# Altium schematic sheet Gateway_Main_Board.SchDoc
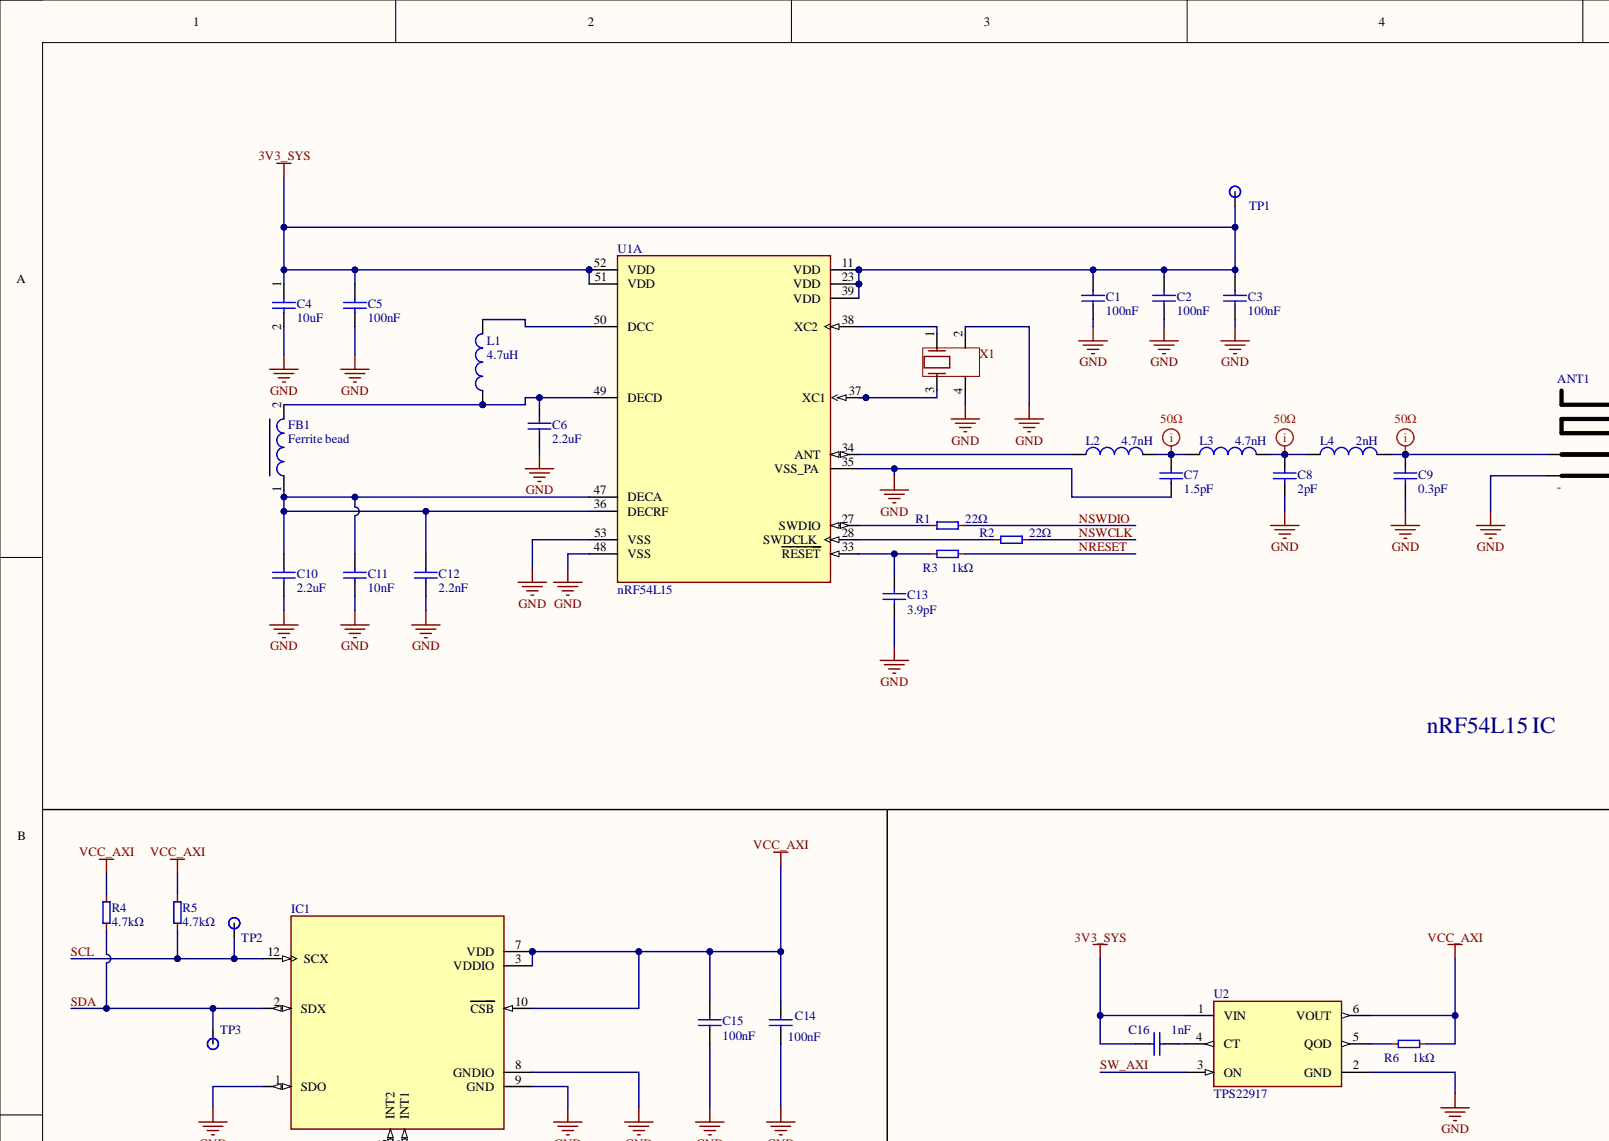
[diagram p0: Gateway_Main_Board.SchDoc, top left]
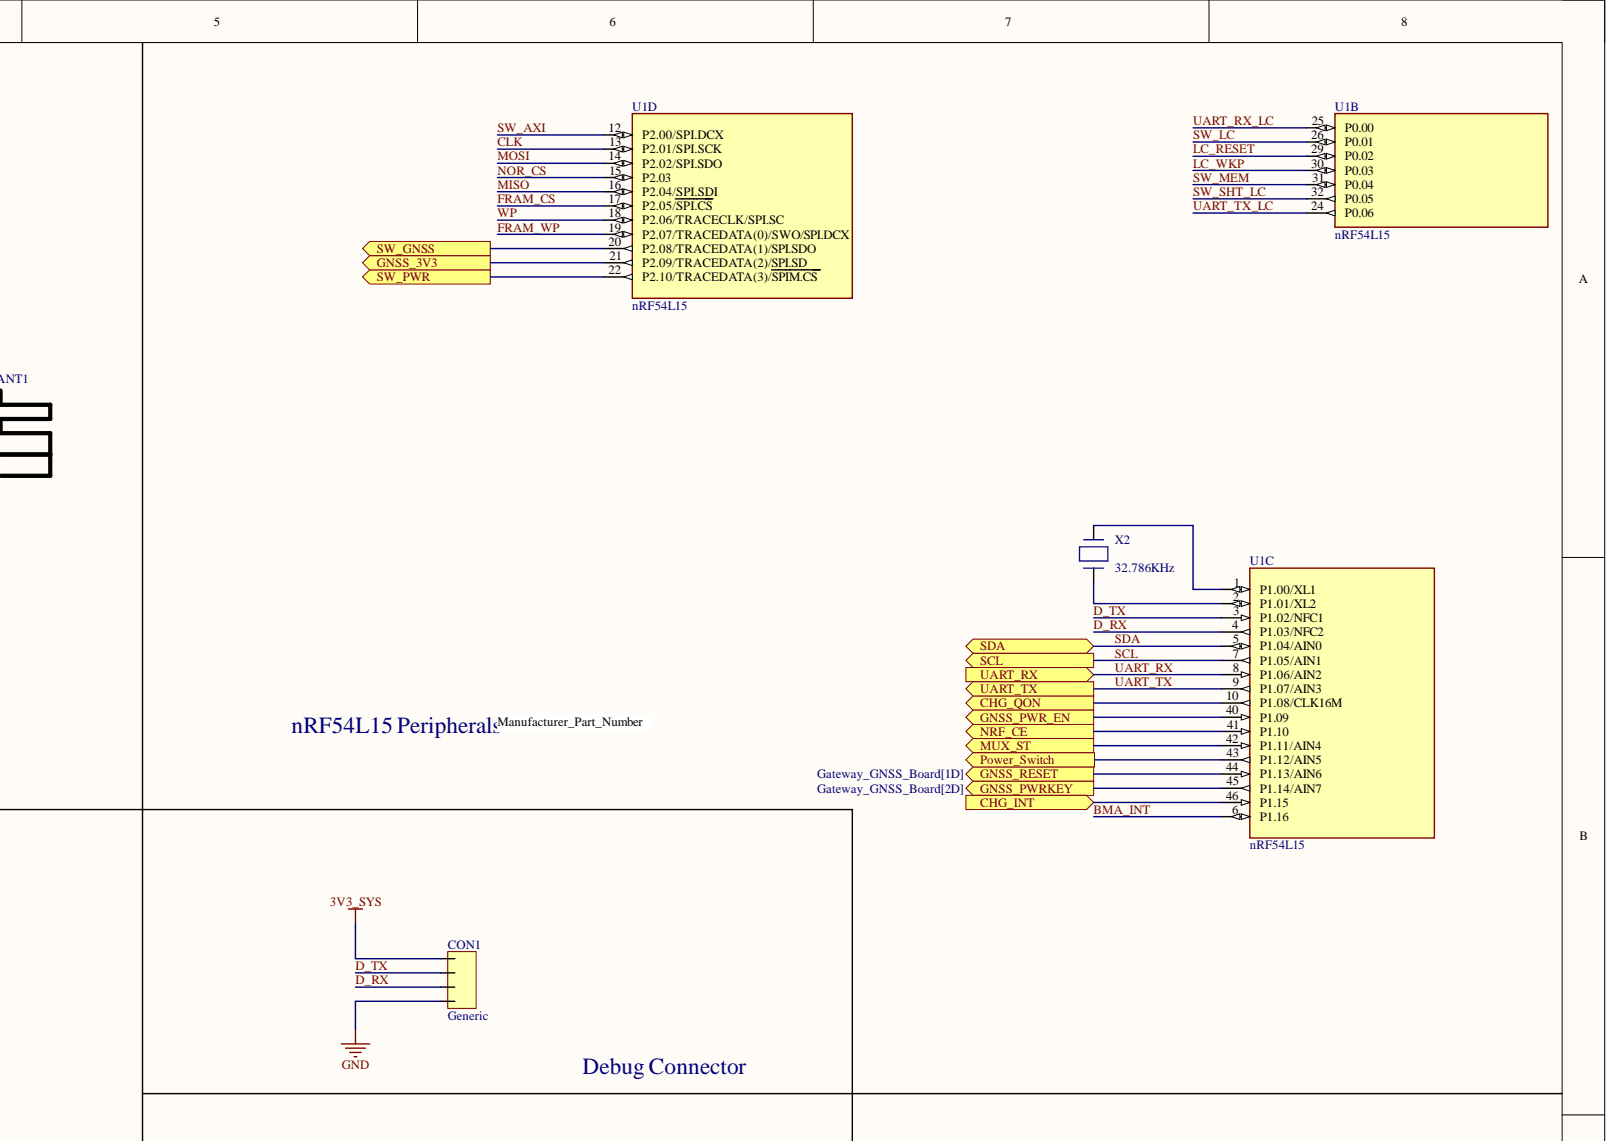
[diagram p1: Gateway_Main_Board.SchDoc, top right]
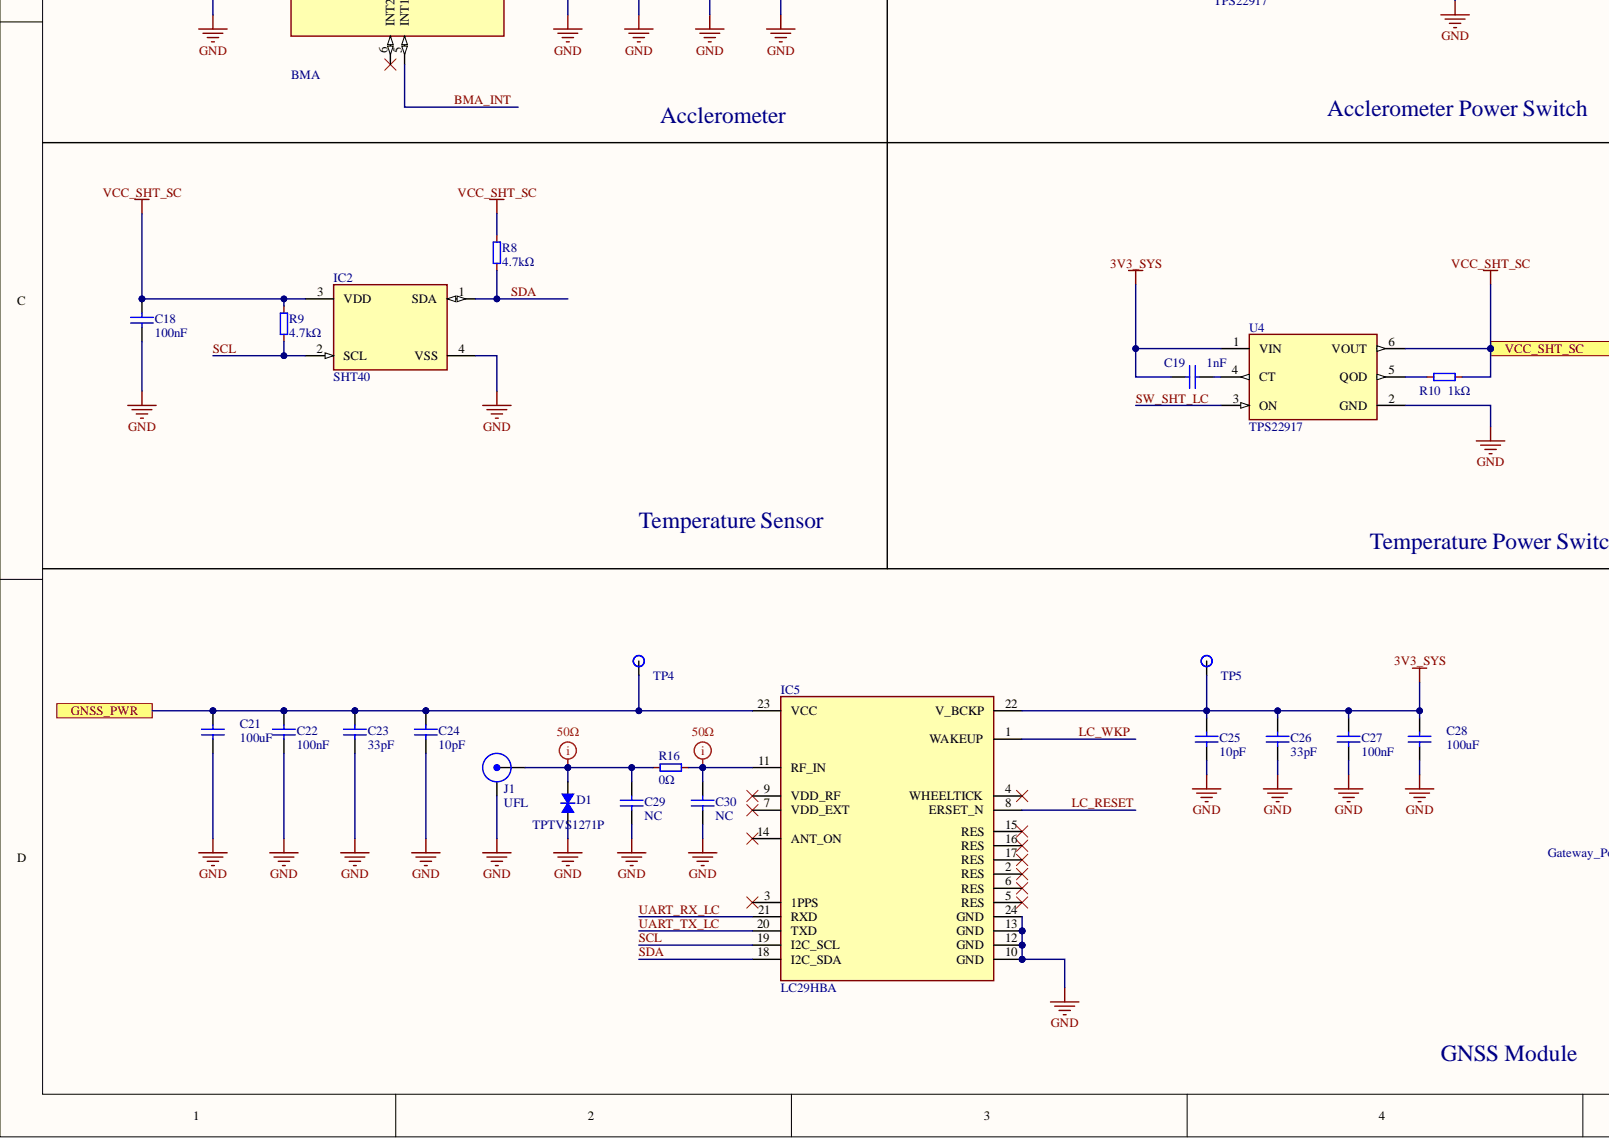
[diagram p2: Gateway_Main_Board.SchDoc, bottom left]
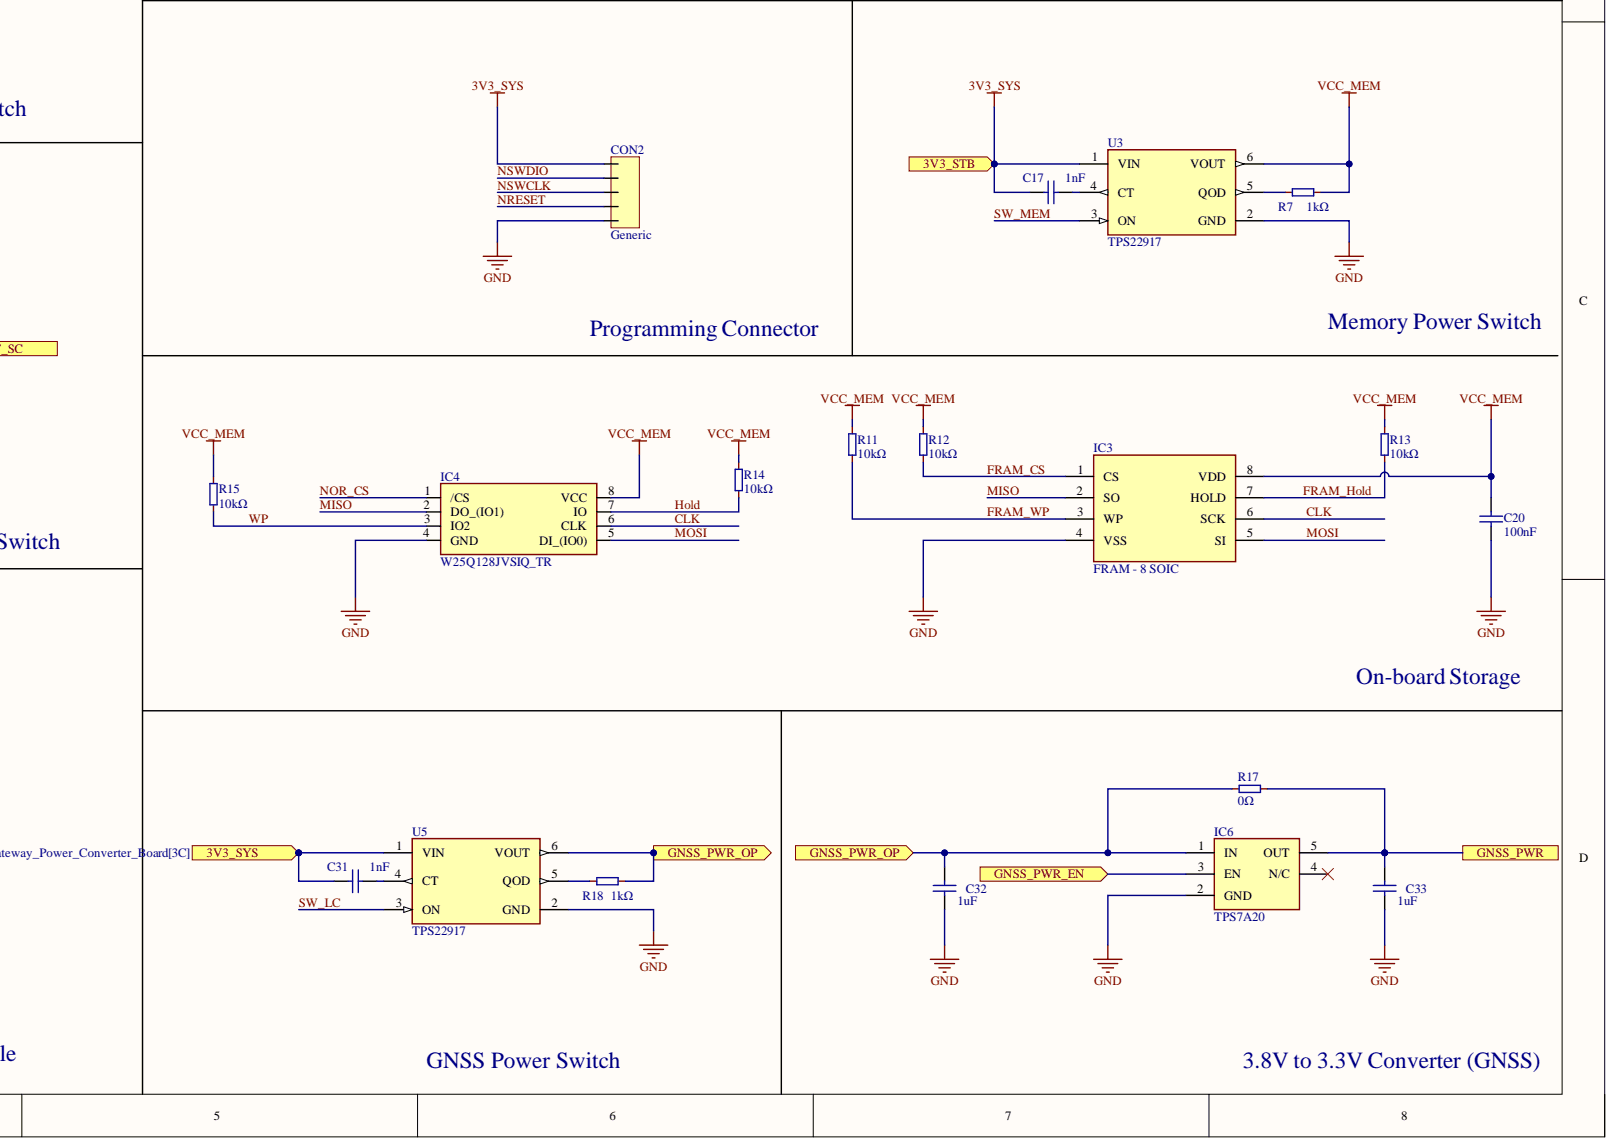
[diagram p3: Gateway_Main_Board.SchDoc, bottom right]

## Parts
ANT1  -  [2.4GHz F Anttena]
C1  100nF  [CAP0402]
C2  100nF  [CAP0402]
C3  100nF  [CAP0402]
C4  10uF  [Cap_0805]
C5  100nF  [CAP0402]
C6  2.2uF  [CAP0402]
C7  1.5pF  [CAP0402]
C8  2pF  [CAP0402]
C9  0.3pF  [CAP0402]
C10  2.2uF  [CAP0402]
C11  10nF  [CAP0402]
C12  2.2nF  [CAP0402]
C13  3.9pF  [CAP0402]
C14  100nF  [CAP0402]
C15  100nF  [CAP0402]
C16  1nF  [CAPC1005X06N]
C17  1nF  [CAPC1005X06N]
C18  100nF  [CAP0402]
C19  1nF  [CAPC1005X06N]
C20  100nF  [CAP0402]
C21  100uF  [CAP1206]
C22  100nF  [CAP0402]
C23  33pF  [CAP0402]
C24  10pF  [CAP0402]
C25  10pF  [CAP0402]
C26  33pF  [CAP0402]
C27  100nF  [CAP0402]
C28  100uF  [CAP1206]
C29  NC  [CAP0402]
C30  NC  [CAP0402]
C31  1nF  [CAPC1005X06N]
C32  1uF  [CAP0402]
C33  1uF  [CAP0402]
CON1  Generic  [4 Pin Relimate]
CON2  Generic  [5 Pin Relimate]
D1  TPTVS1271P  [TVS Diode]
FB1  Ferrite bead  [Ferrite bead]
IC1  BMA  [Bosch_LGA12 pin]
IC2  SHT40  [SHT4x]
IC3  FRAM - 8 SOIC  [SOIC8]
IC4  W25Q128JVSIQ_TR  [SOIC127P780X195-8N]
IC5  LC29HBA  [LC29H]
IC6  TPS7A20  [TPS7A20]
J1  UFL  [UFL]
L1  4.7uH  [IND_0603]
L2  4.7nH  [IND_0402]
L3  4.7nH  [IND_0402]
L4  2nH  [IND_0402]
R1  22Ω  [RESC0402]
R2  22Ω  [RESC0402]
R3  1kΩ  [RESC0402]
R4  4.7kΩ  [RESC0402]
R5  4.7kΩ  [RESC0402]
R6  1kΩ  [RESC0402]
R7  1kΩ  [RESC0402]
R8  4.7kΩ  [RESC0402]
R9  4.7kΩ  [RESC0402]
R10  1kΩ  [RESC0402]
R11  10kΩ  [RESC0402]
R12  10kΩ  [RESC0402]
R13  10kΩ  [RESC0402]
R14  10kΩ  [RESC0402]
R15  10kΩ  [RESC0402]
R16  0Ω  [RESC0402]
R17  0Ω  [RESC0402]
R18  1kΩ  [RESC0402]
TP1  Test Point  [Test Point]  (value not drawn)  (no BOM)
TP2  Test Point  [Test Point]  (value not drawn)  (no BOM)
TP3  Test Point  [Test Point]  (value not drawn)  (no BOM)
TP4  Test Point  [Test Point]  (value not drawn)  (no BOM)
TP5  Test Point  [Test Point]  (value not drawn)  (no BOM)
U1  nRF54L15  [QFN52 (QGAA) Package]  (parts A,B,C,D)
U2  TPS22917  [SOT23-6]
U3  TPS22917  [SOT23-6]
U4  TPS22917  [SOT23-6]
U5  TPS22917  [SOT23-6]
X1  32MHz  [OSC_2016]  (value not drawn)
X2  32.786KHz  [OSC_NDK_NX2012SA]

## Ports
3V3_STB, 3V3_SYS, CHG_INT, CHG_QON, GNSS_3V3, GNSS_PWR, GNSS_PWR_EN, GNSS_PWR_OP, GNSS_PWRKEY, GNSS_RESET, MUX_ST, NRF_CE, Power_Switch, SCL, SDA, SW_GNSS, SW_PWR, UART_RX, UART_TX, VCC_SHT_SC

## Nets
GND: ANT1.2 C1.2 C2.2 C3.2 C4.2 C5.2 C6.2 C7.1 C8.1 C9.1 C10.2 C11.1 C12.2 C13.2 C14.2 C15.2 C18.2 C20.2 C21.1 C22.2 C23.2 C24.2 C25.2 C26.2 C27.2 C28.1 C29.1 C30.1 C32.1 C33.1 CON1.4 CON2.5 D1.2 IC1.1(SDO) IC1.8(GNDIO) IC1.9(GND) IC2.4(VSS) IC3.4(VSS) IC4.4(GND) IC5.10(GND) IC5.12(GND) IC5.13(GND) IC5.24(GND) IC6.2(GND) J1.2 U1.35(VSS_PA) U1.48(VSS) U1.53(VSS) U2.2(GND) U3.2(GND) U4.2(GND) U5.2(GND) X1.2 X1.4
VCC_AXI: C14.1 C15.1 IC1.3(VDDIO) IC1.7(VDD) IC1.10(CSB) R4.2 R5.2 R6.2 U2.6(VOUT)
VCC_MEM: C20.1 IC3.8(VDD) IC4.8(VCC) R7.2 R11.2 R12.2 R13.2 R14.2 R15.2 U3.6(VOUT)
3V3_SYS: C1.1 C2.1 C3.1 C4.1 C5.1 C16.2 C17.2 C19.2 C25.1 C26.1 C27.1 C28.2 CON1.1 CON2.1 IC5.22(V_BCKP) TP1.1 TP5.1 U1.11(VDD) U1.23(VDD) U1.39(VDD) U1.51(VDD) U1.52(VDD) U2.1(VIN) U3.1(VIN) U4.1(VIN) ; port 3V3_STB
BMA_INT: IC1.5(INT1) U1.6(P1.16)
CHG_INT: U1.46(P1.15) ; port CHG_INT
CHG_QON: U1.10(P1.08/CLK16M) ; port CHG_QON
CLK: IC3.6(SCK) IC4.6(CLK) U1.13(P2.01/SPI.SCK)
D_RX: CON1.3 U1.4(P1.03/NFC2)
D_TX: CON1.2 U1.3(P1.02/NFC1)
FRAM_CS: IC3.1(CS) R12.1 U1.17(P2.05/SPI.CS)
FRAM_Hold: IC3.7(HOLD) R13.1
FRAM_WP: IC3.3(WP) R11.1 U1.19(P2.07/TRACEDATA(0)/SWO/SPI.DCX)
GNSS_3V3: U1.21(P2.09/TRACEDATA(2)/SPI.SD) ; port GNSS_3V3
GNSS_PWR: C21.2 C22.1 C23.1 C24.1 C33.2 IC5.23(VCC) IC6.5(OUT) R17.2 TP4.1 ; port GNSS_PWR
GNSS_PWR_EN: IC6.3(EN) U1.40(P1.09) ; port GNSS_PWR_EN
GNSS_PWR_OP: C32.2 IC6.1(IN) R17.1 R18.2 U5.6(VOUT) ; port GNSS_PWR_OP
GNSS_PWRKEY: U1.45(P1.14/AIN7) ; port GNSS_PWRKEY
GNSS_RESET: U1.44(P1.13/AIN6) ; port GNSS_RESET
Hold: IC4.7(IO) R14.1
LC_RESET: IC5.8(ERSET_N) U1.29(P0.02)
LC_WKP: IC5.1(WAKEUP) U1.30(P0.03)
MISO: IC3.2(SO) IC4.2(DO_(IO1)) U1.16(P2.04/SPI.SDI)
MOSI: IC3.5(SI) IC4.5(DI_(IO0)) U1.14(P2.02/SPI.SDO)
MUX_ST: U1.42(P1.11/AIN4) ; port MUX_ST
NOR_CS: IC4.1(/CS) U1.15(P2.03)
NRESET: CON2.4 R3.2
NRF_CE: U1.41(P1.10) ; port NRF_CE
NSWCLK: CON2.3 R2.2
NSWDIO: CON2.2 R1.2
Power_Switch: U1.43(P1.12/AIN5) ; port Power_Switch
SCL: IC1.12(SCX) IC2.2(SCL) IC5.19(I2C_SCL) R5.1 R9.1 TP2.1 U1.7(P1.05/AIN1) ; port SCL
SDA: IC1.2(SDX) IC2.1(SDA) IC5.18(I2C_SDA) R4.1 R8.1 TP3.1 U1.5(P1.04/AIN0) ; port SDA
SW_AXI: U1.12(P2.00/SPI.DCX) U2.3(ON)
SW_GNSS: U1.20(P2.08/TRACEDATA(1)/SPI.SDO) ; port SW_GNSS
SW_LC: U1.26(P0.01) U5.3(ON)
SW_MEM: U1.31(P0.04) U3.3(ON)
SW_PWR: U1.22(P2.10/TRACEDATA(3)/SPIM.CS) ; port SW_PWR
SW_SHT_LC: U1.32(P0.05) U4.3(ON)
UART_RX: U1.8(P1.06/AIN2) ; port UART_RX
UART_RX_LC: IC5.21(RXD) U1.25(P0.00)
UART_TX: U1.9(P1.07/AIN3) ; port UART_TX
UART_TX_LC: IC5.20(TXD) U1.24(P0.06)
VCC_SHT_SC: C18.1 IC2.3(VDD) R8.2 R9.2 R10.2 U4.6(VOUT) ; port VCC_SHT_SC
WP: IC4.3(IO2) R15.1 U1.18(P2.06/TRACECLK/SPI.SC)
NetANT1_1: ANT1.1 C9.2 L4.2
NetC6_1: C6.1 FB1.2 L1.1 U1.49(DECD)
NetC7_2: C7.2 L2.2 L3.1
NetC8_2: C8.2 L3.2 L4.1
NetC10_1: C10.1 C11.2 C12.1 FB1.1 U1.36(DECRF) U1.47(DECA)
NetC13_1: C13.1 R3.1 U1.33(RESET)
NetC16_1: C16.1 U2.4(CT)
NetC17_1: C17.1 U3.4(CT)
NetC19_1: C19.1 U4.4(CT)
NetC29_2: C29.2 D1.1 J1.1 R16.1
NetC30_2: C30.2 IC5.11(RF_IN) R16.2
NetC31_1: C31.1 U5.4(CT)
NetC31_2: C31.2 U5.1(VIN) ; port 3V3_SYS
NetL1_2: L1.2 U1.50(DCC)
NetL2_1: L2.1 U1.34(ANT)
NetR1_1: R1.1 U1.27(SWDIO)
NetR2_1: R2.1 U1.28(SWDCLK)
NetR6_1: R6.1 U2.5(QOD)
NetR7_1: R7.1 U3.5(QOD)
NetR10_1: R10.1 U4.5(QOD)
NetR18_1: R18.1 U5.5(QOD)
NetU1_1: U1.1(P1.00/XL1) X2.2
NetU1_2: U1.2(P1.01/XL2) X2.1
NetU1_37: U1.37(XC1) X1.3
NetU1_38: U1.38(XC2) X1.1

Not connected: IC1 6(INT2); IC5 2(RES) 3(1PPS) 4(WHEELTICK) 5(RES) 6(RES) 7(VDD_EXT) 9(VDD_RF) 14(ANT_ON) 15(RES) 16(RES) 17(RES); IC6 4(N/C)

## Library data of the parts
ANT1 = 2.4GHz Antenna: 2.4GHq Inverted F antenna 1.6mm Thikness; Component Kind: Net Tie; Library Name: macnman_2.0.SchLib
C1-C3 C5 C14 C15 C18 C20 C22 C27 = Capa_0402: Ceramic Capacitor C0G, NP0 0402 100nF 35V; Manufacturer: MURATA; Manufacturer_Part_Number: GRM155R61A104KA01J; Component Kind: Standard; Library Name: macnman_2.0.SchLib
C4 = Cap_0805: Ceramic Capacitor - SMD 0805 10uF 10% 25V X7R; Manufacturer_Part_Number: CL21B106KAYQNNE; Component Kind: Standard; Supplier 1: Evalta; Supplier 2: Robu; Supplier Part Number 1: CL21B106KAYQNNE; Supplier Part Number 2: CL21B106KAYQNNE; Library Name: macnman_3.0.SchLib
C6 C10 = Capa_0402: Ceramic Capacitor - SMD X7R 0402 2.2uF 10V 10%; Manufacturer: Murata; Manufacturer_Part_Number: GRM155Z71A225KE44D; Component Kind: Standard; Supplier 1: Robu; Supplier Part Number 1: GRM155Z71A225KE44D; Library Name: macnman_2.0.SchLib
C7 = CAP_0402: Ceramic Capcitor - SMD 1.5pF 0402 0.05% C0G/NP0 50V; Manufacturer: MURATA; Manufacturer_Part_Number: GJM1555C1H1R5WB01D; Component Kind: Standard; Supplier: Robu; Supplier_Part_Number: GJM1555C1H1R5WB01D; Library Name: macnman_2.0.SchLib
C8 = CAP_0402: Ceramic Capacitor - SMD 0402 2pF 0.25pF C0G/NP0 50V; Manufacturer: MURATA; Manufacturer_Part_Number: GJM1555C1H2R0CB01D; Component Kind: Standard; Supplier: Robu; Supplier_Part_Number: GJM1555C1H2R0CB01D; Library Name: macnman_2.0.SchLib
C9 = CAP_0402: Ceramic Capacitor - SMD 0.3pF 0402 C0G/NP0 0.1% 50V; Manufacturer: MURATA; Manufacturer_Part_Number: GJM1555C1HR30BB01D; Component Kind: Standard; Supplier: Robu; Supplier_Part_Number: GJM1555C1HR30BB01D; Library Name: macnman_2.0.SchLib
C11 = CAP_0402: Ceramic Capacitor - SMD C0G 0402 10nF 50V 5%; Manufacturer: MURATA; Manufacturer_Part_Number: GRM1555C1H103JE01D; Component Kind: Standard; Package: 0402; Supplier 1: Robu; Supplier Part Number 1: GRM1555C1H103JE01D; Library Name: macnman_2.0.SchLib
C12 = CAP_0402: Ceramic Capacitor - SMD X7R 0402 2.2nF 50V 10%; Manufacturer: MURATA; Manufacturer_Part_Number: GRT155R71H222KE01D; Component Kind: Standard; Supplier: Robu; Supplier_Part_Number: GRT155R71H222KE01D; Library Name: macnman_2.0.SchLib
C13 = CAP_0402: Ceramic Capacitor - SMD C0G 0402 3.9pF 50V +-0.25pF; Manufacturer: MURATA; Manufacturer_Part_Number: GJM1555C1H3R9CB01D; Component Kind: Standard; Supplier: Robu; Supplier_Part_Number: GJM1555C1H3R9CB01D; Library Name: macnman_2.0.SchLib
C16 C17 C19 C31 = MCD-00198: Ceramic Capacitor - SMD 1nF 25V C0G 5% 0402; Manufacturer: Murata; Manufacturer_Part_Number: GRT1555C1E102JA02J; Component Kind: Standard; Supplier 1: DigiKey; Supplier 2: Mouser; Supplier Part Number 1: 490-GRT1555C1E102JA02JCT-ND; Supplier Part Number 2: 81-GRT1555C1E102JA2J; Value: 1nF; Library Name: macnman_3.0.SchLib
C21 C28 = CAP_0805: Ceramic Capacitor - SMD 10uF 16V 10% 0805 X7R; Manufacturer: MURATA; Manufacturer_Part_Number: CC0805KKX7R7BB106; Component Kind: Standard; Supplier: Robu; Supplier_Part_Number: CC0805KKX7R7BB106; Library Name: macnman_2.0.SchLib
C23 C26 = Capa_0402: 33pF 50V Capacitor 5% Tolerance CC0402JRNPO9BN330- 0402 Package; Manufacturer: YAGEO; Component Kind: Standard; Manufacturer_Part_Number: CC0402JRNPO9BN330; Library Name: macnman_2.0.SchLib
C24 C25 = Capa_0402: Ceramic Capacitor C0G, NP0 0402 10pF 35V; Manufacturer: YAGEO; Manufacturer_Part_Number: CC0402JRNPO9BN100; Component Kind: Standard; Library Name: macnman_2.0.SchLib
C29 C30 = Capa_0402: Ceramic Capacitor C0G, NC NP0 0402; Manufacturer: YAGEO; Manufacturer_Part_Number: CC0402KRX7R9BB105; Component Kind: Net Tie; Library Name: macnman_2.0.SchLib
C32 C33 = CAP_0402: Ceramic Capacitor - SMD X7R 0402 1uF 10V 10%; Manufacturer: MURATA; Manufacturer_Part_Number: GRM155Z71A105KE01D; Component Kind: Standard; Supplier: Robu; Supplier_Part_Number: GRM155Z71A105KE01D; Library Name: macnman_2.0.SchLib
CON1 = 4 Pin Relimate: 4 Pin Connector; Component Kind: Net Tie; Manufacturer: Generic; Manufacturer_Part_Number: Generic; Library Name: macnman_3.0.SchLib
CON2 = 5 Pin Relimate: 5 Pin Connector; Component Kind: Net Tie; Manufacturer: Generic; Manufacturer_Part_Number: Generic; Library Name: macnman_3.0.SchLib
D1 = TVS Diode: 0.35pF 20kV Bidirectional Discrete TVS; Manufacturer: Tech Public; Component Kind: Standard; Manufacturer_Part_Number: TPTVS1271P; Library Name: macnman_3.0.SchLib
FB1 = Ferrite bead: 120 Ohms @ 100 MHz 1 Power, Signal Line Ferrite Bead 0402 (1005 Metric) 400mA 400mOhm; Manufacturer: Vishay Dale; Manufacturer_Part_Number: ILHB0402ER121VSS11; Component Kind: Standard; Supplier 1: Digikey; Supplier Part Number 1: ILHB0402ER121VSS11; Library Name: macnman_3.0.SchLib
IC1 = BMA: Ultra-low power triaxial accelerometer; Manufacturer: Bosch; Manufacturer_Part_Number: BMA400; Component Kind: Standard; Library Name: macnman_3.0.SchLib
IC2 = SHT40: 4th Gen. Relative Humidity and Temperature I2C Sensor; Manufacturer: Sensirion; Component Kind: Standard; Manufacturer_Part_Number: SHT40; Library Name: macnman_3.0.SchLib
IC3 = FRAM - 8 SOIC: Manufacturer: RAMSEED; Manufacturer_Part_Number: MB85RS512TPNF-G-AMERE2; Component Kind: Standard; Description: FRAM - 8 SOIC; Library Name: macnman_3.0.SchLib
IC4 = W25Q128JVSIQ_TR: FLASH - NOR Memory IC 128Mb (16M x 8) SPI - Quad I/O 8-SOIC; Manufacturer_Name: Winbond; Manufacturer_Part_Number: W25Q128JVSIQ TR; Geometry.Height: 1.95mm; Component Kind: Standard; Datasheet Link: http://www.winbond.com/resource-files/w25q128jv%20spi%20revc%2011162016.pdf; Mouser Part Number: 454-W25Q128JVSIQTR; Mouser Price/Stock: https://www.mouser.co.uk/ProductDetail/Winbond/W25Q128JVSIQ-TR?qs=qSfuJ%252Bfl%2Fd7vTyLA9kNdGw%3D%3D; Library Name: macnman_2.0.SchLib
IC5 = LC29HBA: High-precision, dual-band GNSS module with NAViC, DR and RTK; Manufacturer: Quectel; Component Kind: Standard; Manufacturer_Part_Number: LC29HBA; Supplier 1: Digikey; Supplier Part Number 1: LC29HBA; Library Name: macnman_3.0.SchLib
IC6 = TPS7A20: Ultra-Low-Noise, Low-IQ, High PSRR LDO, 300mA; Manufacturer: Texas Instrument; Manufacturer_Part_Number: TPS7A2033; Component Kind: Standard; Supplier 1: Arrow Electronics; Supplier Part Number 1: TPS7A2033PDQNR; Library Name: macnman_3.0.SchLib
J1 = SMA_T_9.52_NF: SMA Jack, UFL Edge Mount, 50 Ohms; Manufacturer: SAMTEC; Manufacturer_Part_Number: SMA-J-P-H-ST-EM1; Component Kind: Standard; Library Name: macnman_3.0.SchLib
L1 = IND_0603: Fixed Inductor - SMD 4.7UH 620mA 440 Mohm 0603; Manufacturer: MURATA; Manufacturer_Part_Number: LQM18PN4R7MFRL; Component Kind: Standard; Package: 0603; Parameter 1: LQM18PN4R7MFRL; Supplier: Robu; Library Name: macnman_2.0.SchLib
L2 L3 = IND_0402: Fixed Inductor - SMD 4.7nH 700mA 160 mOhm 0402 0.1nH; Manufacturer: MURATA; Manufacturer_Part_Number: LQG15HS4N7B02D; Component Kind: Standard; Package: 0402; Supplier: DIgikey; Supplier Part Number 1: LQG15HS4N7B02D; Library Name: macnman_2.0.SchLib
L4 = IND_0402: Fixed Inductor - SMD 2nH 900mA 90 mOhm 0402 0.2nH; Manufacturer: MURATA; Manufacturer_Part_Number: LQG15HN2N0C02D; Component Kind: Standard; Package: 0402; Supplier: Digikey; Supplier Part Number 1: LQG15HN2N0C02D; Library Name: macnman_2.0.SchLib
R1 R2 = RES_0402: Thin Film Resistors - SMD 22ohm 1% 100PPM 1/16W 0402; Manufacturer: YAGEO; Manufacturer_Part_Number: RC0402FR-0722RL; Component Kind: Standard; ComponentLink1Description: New link; Supplier: Robu; Supplier_Psrt_Number: RC0402FR-0722RL; Library Name: macnman_2.0.SchLib
R3 R6 R7 R10 R18 = RES_0402: Thin Film Resistors - SMD 1K 0.1% 50PPM 1/1 6W 0402 50V; Manufacturer: YAGEO; Manufacturer_Part_Number: RT0402BRE071KL; Component Kind: Standard; ComponentLink1Description: New link; Supplier: Robu; Supplier Part Number: RT0402BRE071KL; Library Name: macnman_2.0.SchLib
R4 R5 R8 R9 = RES_0402: Thin Film Resistors - SMD 4.7K 1% 100PPM 1/10W 0402 100mW; Manufacturer: Panasonic; Manufacturer_Part_Number: ERJ2RKF4701X; Component Kind: Standard; ComponentLink1Description: New link; Supplier: Robu; Supplier_Part_Number: ERJ2RKF4701X; Library Name: macnman_2.0.SchLib
R11-R15 = Resister: Thick Flim Resistor - 10k 0402 100ppm 1% 1/10W; Manufacturer: Pansonic; Manufacturer_Part_Number: ERJ2RKF1002X; Component Kind: Standard; Package: 0402; Supplier 1: Robu; Supplier Part Number 1: P10.0KLCT-ND; Library Name: macnman_3.0.SchLib
R16 R17 = RES_0402: Thick Film Resistors - SMD 0Ohm 0402 1/16W; Manufacturer: YAGEO; Manufacturer_Part_Number: RC0402FR-070RL; Component Kind: Standard; ComponentLink1Description: New link; Supplier: Robu; Supplier_Part_Number: RC0402FR-070RL; Library Name: macnman_2.0.SchLib
TP1-TP5 = Test Point: Test Point 1mm; Library Name: macnman_2.0.SchLib
U1 = nRF54L15: Wireless SoCs, Multiprotocol 2.4 GHz radio, Arm Cortex M33 processor, 128MHz, QFN52, 6x6; Manufacturer: Nordic Semiconductor; Parameter 2: NRF54L15-QGAA-R; Component Kind: Standard; Supplier 1: Future Electronics; Supplier Part Number 1: NRF54L15-QGAA-R; Library Name: macnman_3.0.SchLib
U2-U5 = TPS22917: Power Switch ICs - Power Distribution 5.5-V, 2-A, 80-m , 10-nA leakage load switch adj. rise time and adj output discharge 6-SOT-23; Manufacturer: Texas Instruments; Component Kind: Standard; Manufacturer_Part_Number: TPS22917; Package: SOT23-6; Library Name: macnman_2.0.SchLib
X1 = OSC_2016: Crystal Osc - SMD 2016 4 Pin 32Mhz 8pF 30ppm; Manufacturer: KYOCERA AVX; Manufacturer_Part_Number: CX2016SA32000D0WRQG1; Component Kind: Standard; Package: 2016; Supplier 1: DigiKey; Supplier Part Number 1: CX2016SA32000D0WRQG1; Library Name: macnman_2.0.SchLib
X2 = OSC_2012: Crystal Osc 2012 32.768kHz 2Pin 12pF; Manufacturer: Micro Crystal AG; Manufacturer_Part_Number: CM8V-T1A-LOW-ESR-32.768KHZ-9PF-20-TA-QC; Component Kind: Standard; Package: 2012; Supplier 1: DigiKey; Supplier Part Number 1: CM8V-T1A-LOW-ESR-32.768KHZ-9PF-20-TA-QCCT-ND; Value: 32.786KHz; Library Name: macnman_2.0.SchLib
